# Altium schematic sheet Power.SchDoc
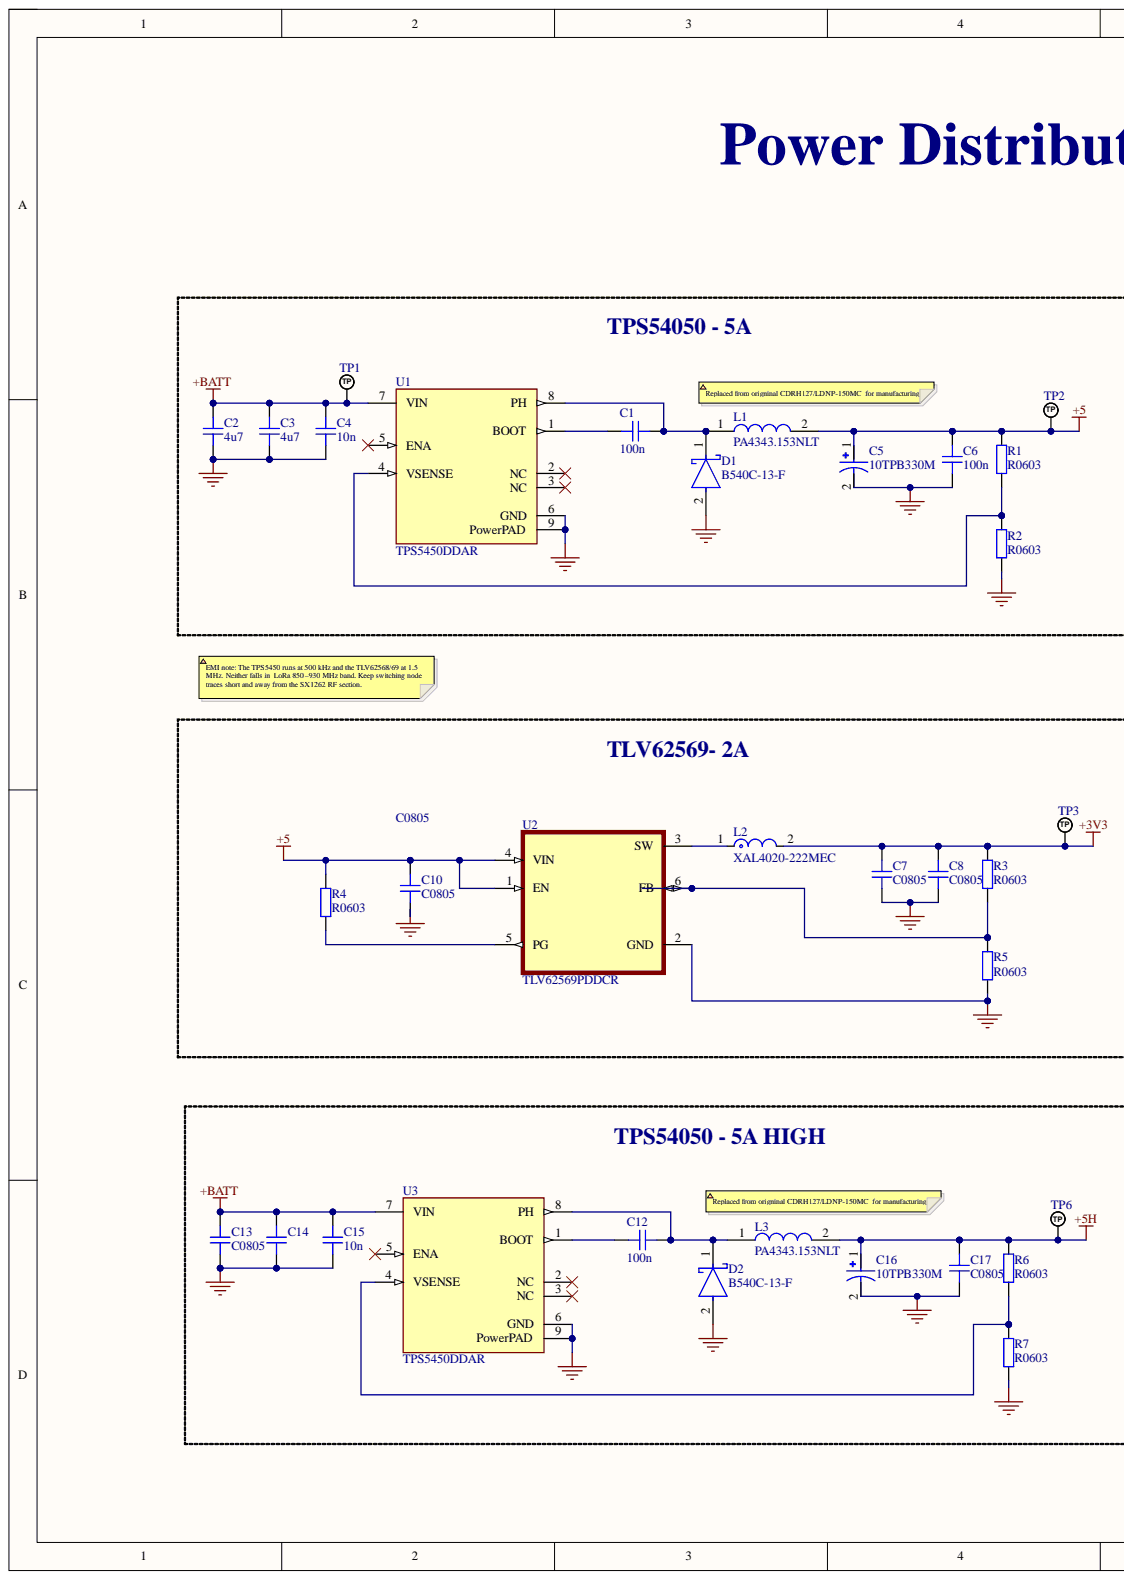
[diagram p0: Power.SchDoc, left]
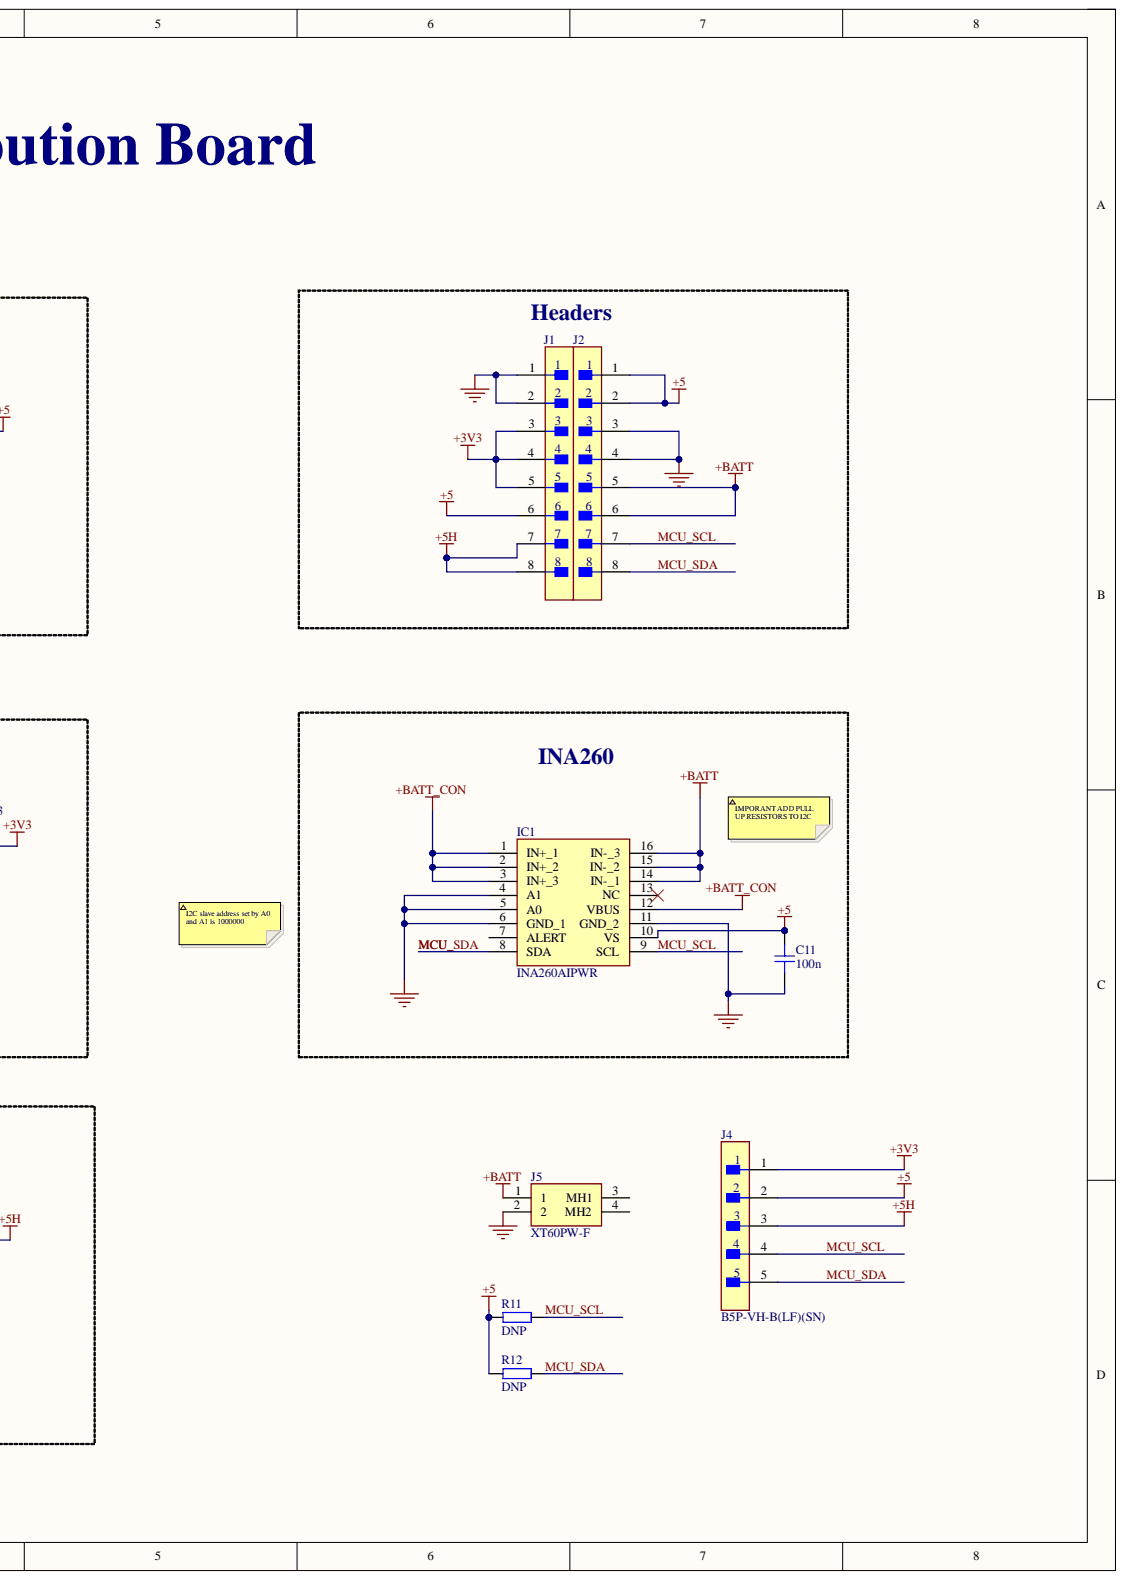
[diagram p1: Power.SchDoc, right]

## Parts
C1  100n  [CAP_0603]
C2  4u7  [CAP_0805]
C3  4u7  [CAP_0805]
C4  10n  [CAP_0603]
C5  10TPB330M  [CAP_10TPB330M]
C6  100n  [CAP_0805]
C7  C0805  [CAP_0805]
C8  C0805  [CAP_0805]
C10  C0805  [CAP_0805]
C11  100n  [CAP_0603]
C12  100n  [CAP_0603]
C13  C0805  [CAP_0805]
C14  C0805  [CAP_0805]
C15  10n  [CAP_0603]
C16  10TPB330M  [CAP_10TPB330M]
C17  C0805  [CAP_0805]
D1  B540C-13-F  [FP-SMC-MFG]
D2  B540C-13-F  [FP-SMC-MFG]
IC1  INA260AIPWR  [SOP65P640X120-16N]
J1  TSW-108-08-G-S  [FP-TSW-108-08-G-S-MFG]  (value not drawn)
J2  TSW-108-08-G-S  [FP-TSW-108-08-G-S-MFG]  (value not drawn)
J4  B5P-VH-B(LF)(SN)  [FP-B5P-VH-B_LF_SN-MFG]
J5  XT60PW-F  [XT60PWF]
L1  PA4343.153NLT  [INDPM140128X650N]
L2  XAL4020-222MEC  [FP-XAL4020-MFG]
L3  PA4343.153NLT  [INDPM140128X650N]
R1  R0603  [RES_0603]
R2  R0603  [RES_0603]
R3  R0603  [RES_0603]
R4  R0603  [RES_0603]
R5  R0603  [RES_0603]
R6  R0603  [RES_0603]
R7  R0603  [RES_0603]
R11  DNP  [RES_0402]
R12  DNP  [RES_0402]
TP1  TEST_POINT_RND_1MM  [PAD_RND_1MM]  (value not drawn)  (no BOM)
TP2  TEST_POINT_RND_1MM  [PAD_RND_1MM]  (value not drawn)  (no BOM)
TP3  TEST_POINT_RND_1MM  [PAD_RND_1MM]  (value not drawn)  (no BOM)
TP6  TEST_POINT_RND_1MM  [PAD_RND_1MM]  (value not drawn)  (no BOM)
U1  TPS5450DDAR  [FP-DDA0008J-MFG]
U2  TLV62569PDDCR  [SOT95P280X110-6N]
U3  TPS5450DDAR  [FP-DDA0008J-MFG]

## Nets
+3V3: C7.1 C8.1 J1.3 J1.4 J1.5 J4.1 L2.2 R3.2 TP3.1
+5: C5.1 C6.1 C10.1 C11.2 IC1.10(VS) J1.6 J2.1 J2.2 J4.2 L1.2 R1.2 R4.2 R11.1 R12.1 TP2.1 U2.1(EN) U2.4(VIN)
+5H: C16.1 C17.1 J1.7 J1.8 J4.3 L3.2 R6.2 TP6.1
+BATT: C2.1 C3.1 C4.2 C13.1 C14.1 C15.2 IC1.14(IN-_1) IC1.15(IN-_2) IC1.16(IN-_3) J2.5 J2.6 J5.1 TP1.1 U1.7(VIN) U3.7(VIN)
+BATT_CON: IC1.1(IN+_1) IC1.2(IN+_2) IC1.3(IN+_3) IC1.12(VBUS)
GND: C2.2 C3.2 C4.1 C5.2 C6.2 C7.2 C8.2 C10.2 C11.1 C13.2 C14.2 C15.1 C16.2 C17.2 D1.2 D2.2 IC1.4(A1) IC1.5(A0) IC1.6(GND_1) IC1.11(GND_2) J1.1 J1.2 J2.3 J2.4 J5.2 R2.1 R5.1 R7.1 U1.6(GND) U1.9(PowerPAD) U2.2(GND) U3.6(GND) U3.9(PowerPAD)
MCU_: IC1.8(SDA) J2.8 J4.5 R12.2
MCU_SCL: IC1.9(SCL) J2.7 J4.4 R11.2
NetC1_1: C1.1 D1.1 L1.1 U1.8(PH)
NetC1_2: C1.2 U1.1(BOOT)
NetC12_1: C12.1 D2.1 L3.1 U3.8(PH)
NetC12_2: C12.2 U3.1(BOOT)
NetL2_1: L2.1 U2.3(SW)
NetR1_1: R1.1 R2.2 U1.4(VSENSE)
NetR3_1: R3.1 R5.2 U2.6(FB)
NetR4_1: R4.1 U2.5(PG)
NetR6_1: R6.1 R7.2 U3.4(VSENSE)

Not connected: IC1 7(ALERT) 13(NC); J5 3(MH1) 4(MH2); U1 2(NC) 3(NC) 5(ENA); U3 2(NC) 3(NC) 5(ENA)

## Library data of the parts
C1 C4 C11 C12 C15 = CAP_0603: Capacitor SMD 0603; Component Kind: Standard; Library Name: Powersch.SchLib
C2 C3 C6-C8 C10 C13 C14 C17 = CAP_0805: Capacitor SMD 0805; Component Kind: Standard; Library Name: Powersch.SchLib
D1 D2 = CMP-2000-05097-3: DIODE SCHOTTKY 40V 5A SMC; Manufacturer: Diodes Inc.; Automotive Grade: NA; Capacitance: 300pF; Max Junction Temp: +150°C; Max Surge Forward Current: 100A; Peak Reverse Current: 0.5mA; Standoff Height: 0.1mm; Value: 300pF; Automotive: No; Category: Diode; Component Kind: Standard; ComponentLink2Description: Datasheet; ComponentLink2URL: https://www.diodes.com/assets/Datasheets/ds13012.pdf; Device Class L1: Discrete Semiconductors; Device Class L2: Diodes; Device Class L3: Schottky Diodes; Digikey Description: DIODE SCHOTTKY 40V 5A SMC; Footprint Url: https://www.diodes.com/assets/Package-Files/SMC.pdf; Forward Current: 5A; Height: 2.5mm; Lead Free: Yes; Library Name: Altium Content Vault; Manufacturer Part Number: B540C-13-F; Max Repetitive Reverse Voltage: 40V; Mouser Description: Diode: Schottky rectifying; SMD; 40V; 5A; SMC; Package: reel, tape; Octopart: https://octopart.com/b540c-13-f-diodes+inc.-325873; Package: SMC; Reverse Voltage: 40V; RoHS: TRUE; Temperature: -55°C to +150°C; Temperature Range High: +150°C; Temperature Range Low: -55°C
IC1 = INA260AIPWR: Integrated Circuit; Manufacturer_Name: Texas Instruments; Arrow Part Number: INA260AIPWR; Arrow Price/Stock: https://www.arrow.com/en/products/ina260aipwr/texas-instruments.html?utm_currency=USD&region=nac; Component Kind: Standard; Datasheet Link: http://www.ti.com/lit/gpn/INA260; Height: 1.2mm; Manufacturer_Part_Number: INA260AIPWR; Mouser Part Number: 595-INA260AIPWR; Mouser Price/Stock: https://www.mouser.co.uk/ProductDetail/Texas-Instruments/INA260AIPWR?qs=vcbl%252BK4rRldNzXQDYx03SQ%3D%3D; Library Name: Powersch.SchLib
J1 J2 = CMP-02766-000001-1: CONN HEADER VERT 8POS 2.54MM; Manufacturer: Samtec; Conn Gender: Male; Conn Orientation: Straight; Number Of Contacts: 8; Automotive: No; Category: Conn; Component Kind: Standard; ComponentLink2Description: Datasheet; ComponentLink2URL: http://suddendocs.samtec.com/catalog_english/tsw_th.pdf; Contact Material: Gold,Nickel; Device Class L1: Connectors; Device Class L2: Headers and Wire Housings; Device Class L3: unset; Digikey Description: CONN HEADER VERT 8POS 2.54MM; Footprint Url: http://suddendocs.samtec.com/prints/tsw-xxx-xx-x-x-xx-xxx-footprint.pdf; Height: 8.71mm; Lead Free: Yes; Library Name: Altium Content Vault; Manufacturer Part Number: TSW-108-08-G-S; Mouser Description: TSW - Samtec 2.54mm Pin Header 13.46mm Overall Pin Length 5.08mm Tail; Number Of Rows: 1; Octopart: https://octopart.com/tsw-108-08-g-s-samtec-272653; Package: TSW-108-08-G-S; Pitch: 2.54mm; RoHS: TRUE; Temperature: -55°C to +125°C; Temperature Range High: +125°C; Temperature Range Low: -55°C
J4 = CMP-17439-000483-1: CONN HEADER VERT 5POS 3.96MM; Contact Resistance: 20mR; Max Current Rating: 10A; Max Voltage Rating (AC): 250V; Number of Positions: 5; Color: White; Component Kind: Standard; Connector Type: Header; Contact Finish - Mating: TIN; Contact Material: Brass; Contact Plating: Copper; Contact Shape: Square; Contact Type: Male Pin; Depth: 8.5mm; Fastening Type: Ramp; Flammability Rating: UL94 V-0; Housing Color: White; Insulation Color: Natural; Insulation Material: PBT; Lead Free: Lead Free; Length: 19.74mm; Library Name: Altium Content Vault; Max Operating Temperature: 85°C; Min Operating Temperature: -25°C; Number of Pins: 5; Number of Rows: 1; Orientation: Straight; Packaging: Bulk; Pitch: 3.96mm; Series: VH; Termination: Solder; Voltage Rating: 250V
J5 = XT60PW-F: Connector; Manufacturer_Name: Amass; Component Kind: Standard; Datasheet Link: https://www.tme.eu/Document/1191bc2fa3aee3c446e5a895fd8f7983/XT60PW-F.pdf; Height: 8.4mm; Manufacturer_Part_Number: XT60PW-F
L1 L3 = PA4343.153NLT: Inductor; Manufacturer_Name: Yageo Group; Arrow Part Number: PA4343.153NLT; Arrow Price/Stock: https://www.arrow.com/en/products/pa4343.153nlt/pulse-electronics-corporation; Component Kind: Standard; Datasheet Link: https://datasheet.datasheetarchive.com/originals/distributors/DKDS-10/182394.pdf; Height: 6.5mm; Manufacturer_Part_Number: PA4343.153NLT; Mouser Part Number: 673-PA4343.153NLT; Mouser Price/Stock: https://www.mouser.co.uk/ProductDetail/Pulse-Electronics/PA4343153NLT?qs=%2FzQKu7YvIMa1YhPbm59etw%3D%3D; Library Name: Powersch.SchLib
L2 = CMP-11268-000134-1: Fixed Inductors 2.2uH Shld 20% 5.5A 38.7mOhms AECQ2; Core Material: Composite; DC Resistance (DCR): 38.7mR; Saturation Current: 5.6A; Self Resonant Frequency: 52MHz; Shielding: Shielded; Test Frequency: 100kHz; Case/Package: SMD/SMT; Component Kind: Standard; Height: 2.1mm; Inductance: 2.2uH; Inductance Tolerance: 20%; Lead Free: Contains Lead; Length: 4.3mm; Library Name: Altium Content Vault; Max DC Current: 5.5A; Max Operating Temperature: 125°C; Min Operating Temperature: -40°C; Mount: Surface Mount; Package Quantity: 1000; Packaging: Tape and Reel; RMS Current (Irms): 5.5A; RoHS Compliant: Yes; Series: XAL4020; Termination: SMD/SMT; Tolerance: 20%; Width: 4.3mm
R1-R7 = RES_0603: Resistor SMD 0603; Component Kind: Standard; Library Name: Powersch.SchLib
R11 R12 = RES_0402: Resistor SMD 0402; Component Kind: Standard; Library Name: Powersch.SchLib
TP1-TP3 TP6 = CMP-019-000001-1: Test Point Round 1mm (NO BOM); Design Item ID: TEST_POINT_RND_1MM; Library Name: Shravan Talapady; Source: Schematic-Lib-PhilsLab.SchLib
U1 U3 = CMP-04918-000100-1: IC REG BUCK ADJUSTABLE 5A 8SOPWR; Manufacturer: Texas Instruments; Max Duty Cycle: 89%; Max Junction Temp: +125°C; Max Supply Voltage: 36V; Min Supply Voltage: 5.5V; Nominal Supply Current: 3mA; Number Of Outputs: 1; Output Type: AdjustableProgrammable; Output Voltage: 1.22-31V; Standoff Height: 0mm; Switching Frequency: 500kHz; Automotive: No; Category: IC; Component Kind: Standard; ComponentLink2Description: Datasheet; ComponentLink2URL: https://www.ti.com/lit/ds/symlink/tps5450.pdf; Device Class L1: Integrated Circuits (ICs); Device Class L2: Power Management ICs; Device Class L3: Voltage Regulators - Switching; Digikey Description: IC REG BUCK ADJUSTABLE 5A 8SOPWR; Height: 1.7mm; Ipc Land Pattern Name: SOIC127P600X170-8; Lead Free: Yes; Library Name: Altium Content Vault; Manufacturer Part Number: TPS5450DDAR; Mouser Description: Conv DC-DC 5.5V to 36V Step Down Single-Out 1.22V to 31V 5A 8-Pin HSOP EP T/R; Octopart: https://octopart.com/tps5450ddar-texas+instruments-7105511; Output Current: 5A; Package: DDA0008J; RoHS: TRUE; Switching Topology: Buck; Temperature: -40°C to +125°C; Temperature Range High: +125°C; Temperature Range Low: -40°C
U2 = TLV62569PDDCR: 2.5V-5.5V input, 2-A high efficiency step-down buck converter in SOT23 and SOT563 package; MF: Texas Instruments; Check_prices: https://www.snapeda.com/parts/TLV62569PDDCR/Texas+Instruments/view-part/?ref=eda; Component Kind: Standard; MP: TLV62569PDDCR; Package: SOT-23-THN-6 Texas Instruments; SnapEDA_Link: https://www.snapeda.com/parts/TLV62569PDDCR/Texas+Instruments/view-part/?ref=snap; Library Name: Powersch.SchLib
C5 C16 = 10TPB330M: Availability: Unavailable; Component Kind: Standard; MP: 10TPB330M; Package: None; Price: None; Library Name: Powersch.SchLib
